# Altium schematic sheet Aquarium_LED_Driver.SchDoc(Aquarium_LED_Driver)
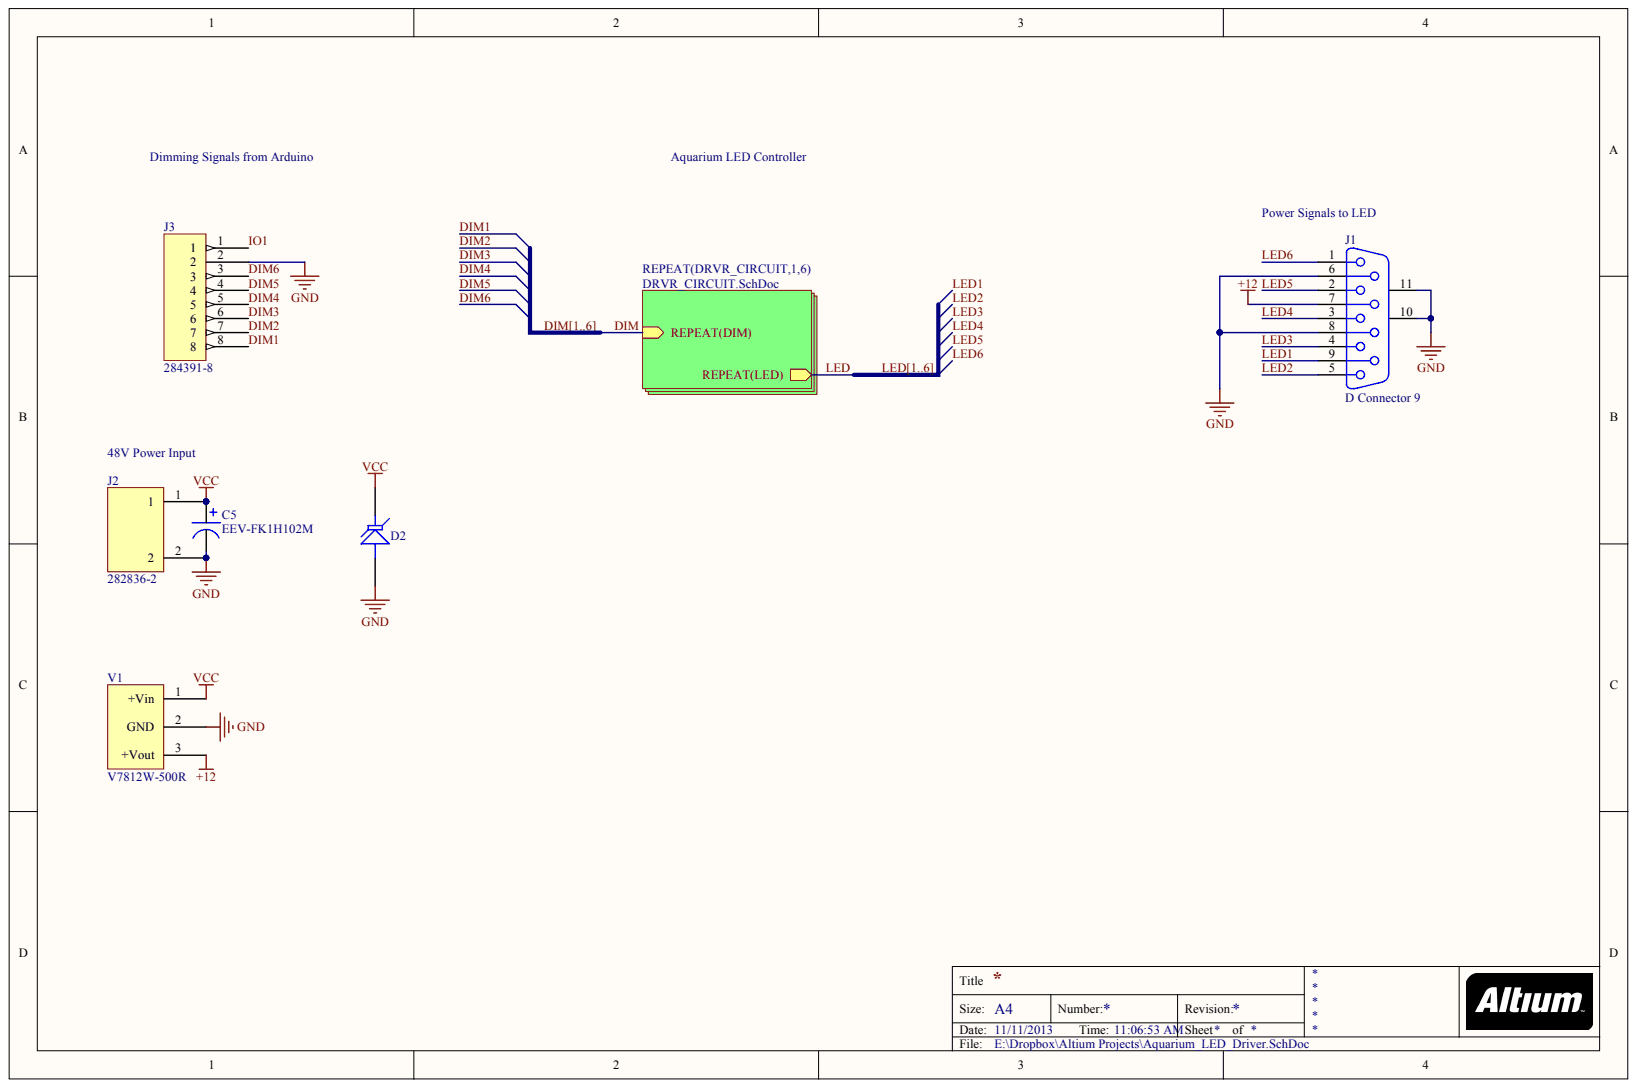
[diagram p0: Aquarium_LED_Driver.SchDoc]

## Parts
C5  EEV-FK1H102M
D2  Tranzorb  (value not drawn)
J1  D Connector 9
J2  282836-2
J3  284391-8
V1  V7812W-500R

## Nets
+12: J1.7 V1.3
GND: C5.1 D2.1 J1.6 J1.8 J1.10 J1.11 J2.2 J3.2 V1.2
VCC: C5.2 D2.2 J2.1 V1.1
DIM1: J3.8  [also labelled DIM]
DIM2: J3.7  [also labelled DIM]
DIM3: J3.6  [also labelled DIM]
DIM4: J3.5  [also labelled DIM]
DIM5: J3.4  [also labelled DIM]
DIM6: J3.3  [also labelled DIM]
IO1: J3.1
LED1: J1.9  [also labelled LED]
LED2: J1.5  [also labelled LED]
LED3: J1.4  [also labelled LED]
LED4: J1.3  [also labelled LED]
LED5: J1.2  [also labelled LED]
LED6: J1.1  [also labelled LED]

## Library data of the parts
J1 = (no symbol name): PackageDocument: O; Positions: 9; Gender: Receptacle; Published: 15-Jun-2001; LatestRevisionDate: 17-Jul-2002; LatestRevisionNote: Re-released for DXP Platform.; DatasheetDocument: 1-Sep-1999; PackageReference: 788750; Orientation: Right Angle; Publisher: Altium Limited
C5 D2 J2 J3 V1 = (no symbol name)
  D2: Published: 24-Mar-1999; LatestRevisionDate: 17-Jul-2002; LatestRevisionNote: Re-released for DXP Platform.; PackageReference: DO-7; PackageDescription: Axial Lead Diode; Publisher: Altium Limited
